# Altium schematic sheet Accesoire antenne et buzzer.SchDoc("Accesoire antenne et buzzer")
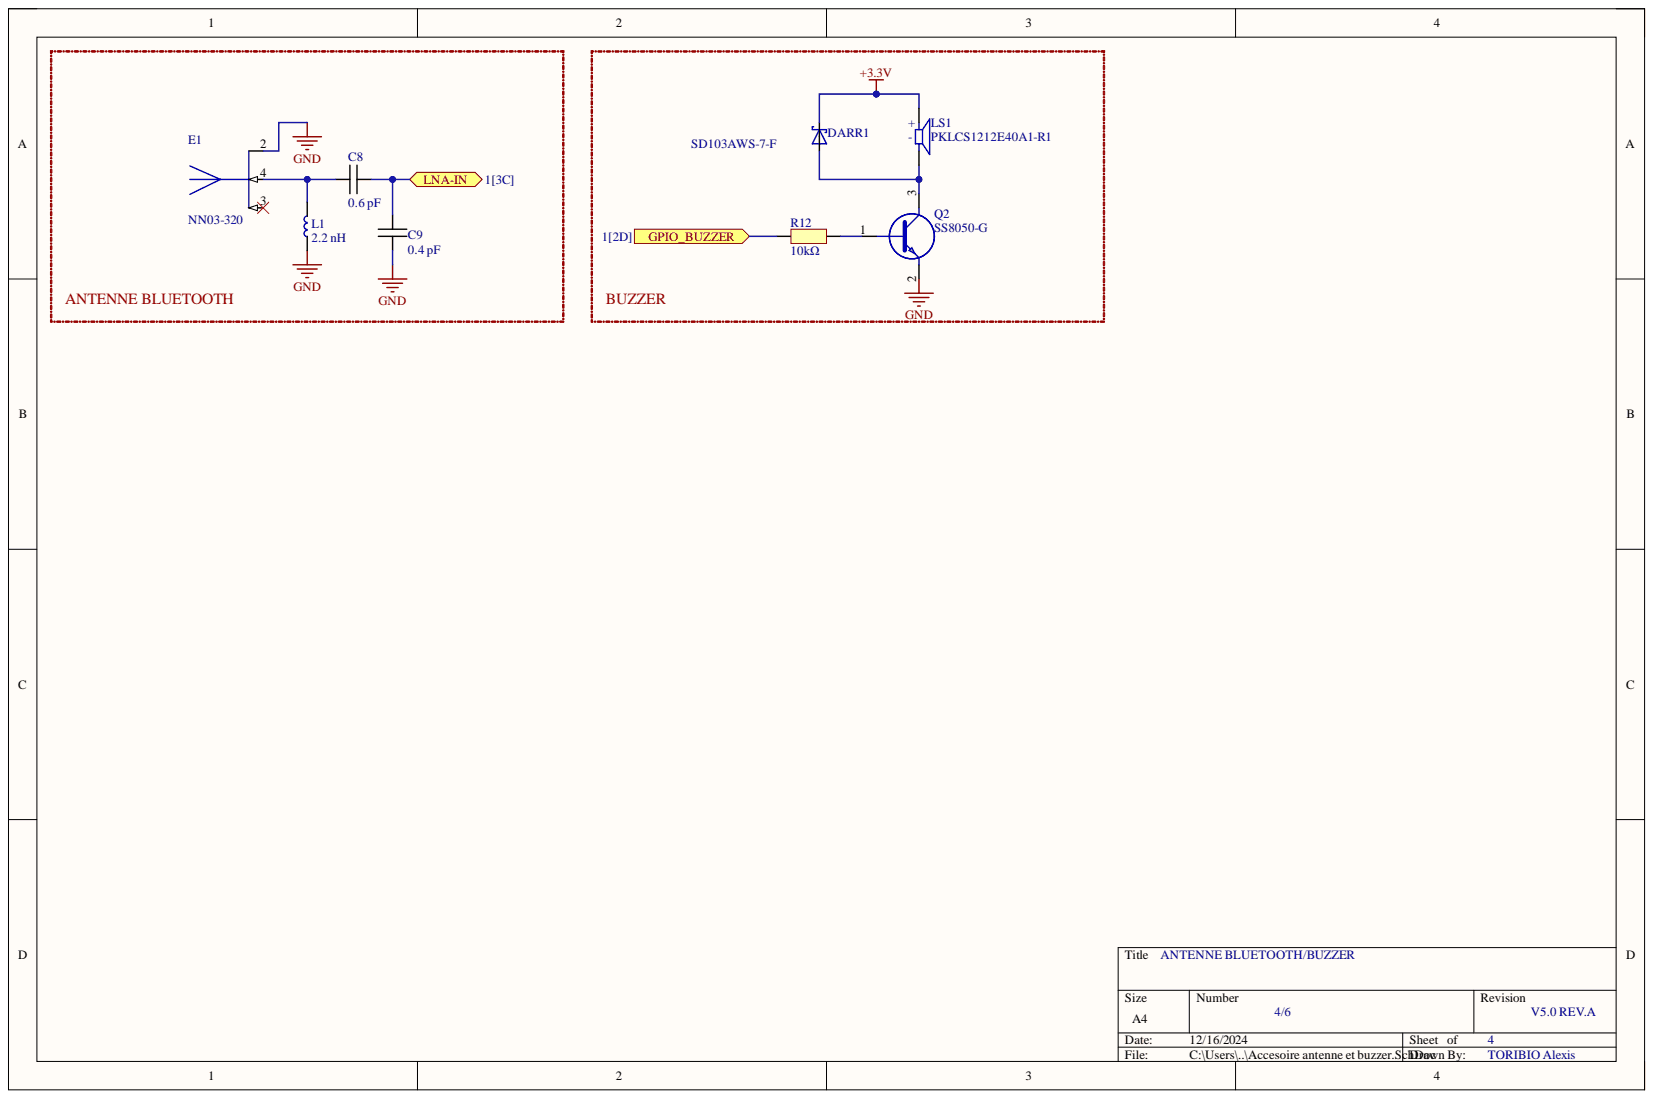
[diagram p0: Accesoire antenne et buzzer.SchDoc]

## Parts
C8  0.6 pF  [CAPC1005X06N]
C9  0.4 pF  [CAPC1005X06N]
DARR1  SD103AWS-7-F  [SD103AWS-7-F]
E1  NN03-320  [XCVR_NN03-320]
L1  2.2 nH  [LQPC1005X06N]
LS1  PKLCS1212E40A1-R1  [SPKR_PKLCS1212E40A1-R1]
Q2  SS8050-G  [SS8050-G]
R12  10kΩ  [RESC1005X06N]

## Ports
GPIO_BUZZER, LNA-IN

## Nets
+3.3V: DARR1.1 LS1.P
GND: C9.2 E1.2 L1.1 Q2.2
GPIO_BUZZER: R12.1 ; port GPIO_BUZZER
LNA-IN: C8.1 C9.1 ; port LNA-IN
NetC8_2: C8.2 E1.4 L1.2
NetDARR1_2: DARR1.2 LS1.N Q2.3
NetQ2_1: Q2.1 R12.2

Not connected: E1 3

## Library data of the parts
C8 C9 = Capacitor: Component Kind: Standard; Library Name: SCHLibrary.SchLib
DARR1 = SD103AWS-7-F: Diode Array 3 Independent Schottky 40V 175mA Surface Mount 6-TSSOP, SC-88, SOT-363; Component Kind: Standard; Library Name: SCHLibrary.SchLib
E1 = NN03-320: Dual port antenna booster for GNSS, Bluetooth, 5G and UWB (1561 - 1606MHz, 2400 - 2500MHz, 3400 - 3800MHz, 3100 - 4800MHz and 6000 - 10600 MHz), signal booster; Manufacturer_Name: Ignion; Component Kind: Standard; Library Name: SCHLibrary.SchLib; Manufacturer_Part_Number: NN03-320; Mouser Part Number: 128-NN03-320
L1 = Bobine: Component Kind: Standard; Library Name: SCHLibrary.SchLib
LS1 = PKLCS1212E40A1-R1: Buzzers Transducer, Externally Driven Piezo 1.5V 2kHz 70dB @ 1.5V, 10cm Surface Mount Solder Pads; MF: Murata Electronics; Availability: Unavailable; Component Kind: Standard; Library Name: SCHLibrary.SchLib; MP: PKLCS1212E40A1-R1; Package: None; Price: None
Q2 = SS8050-G: Bipolar Transistors - BJT NPN TRANSISTOR 1.5A 40V; Component Kind: Standard; Library Name: SCHLibrary.SchLib
R12 = Resistor: Component Kind: Standard; Library Name: SCHLibrary.SchLib
